# Altium schematic sheet ERF01.SchDoc
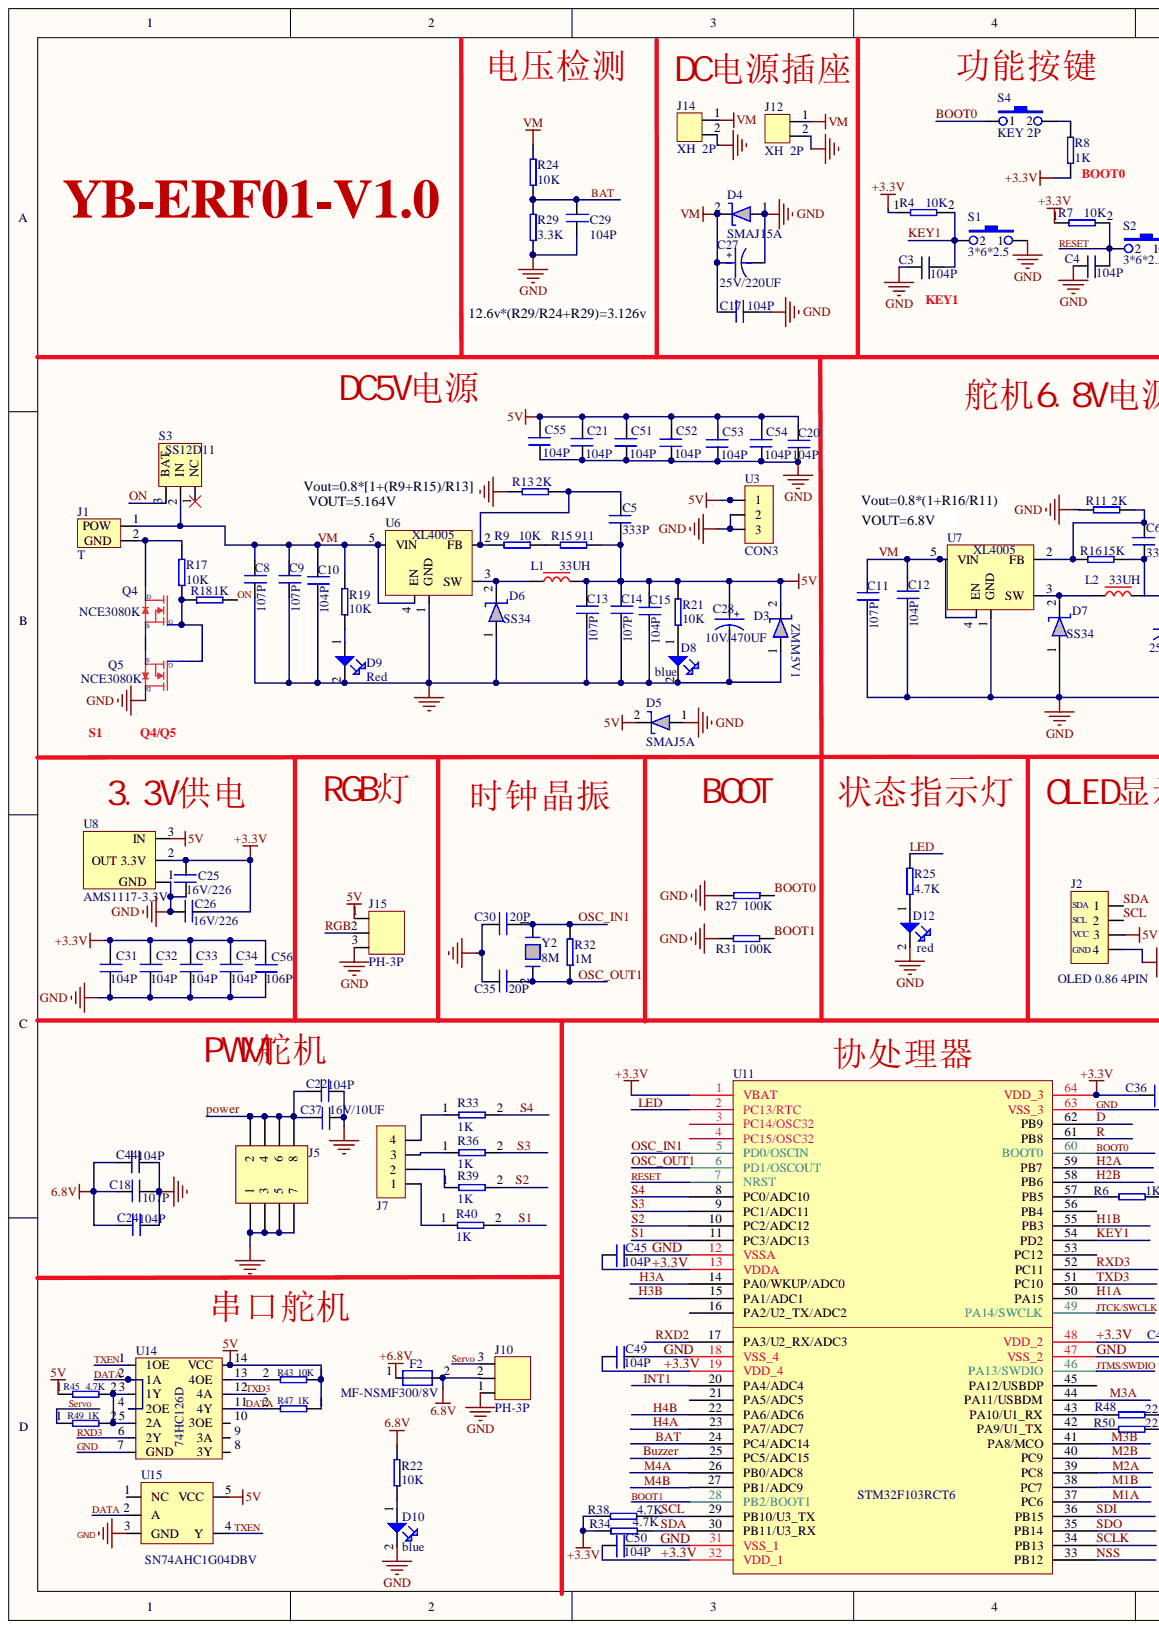
[diagram p0: ERF01.SchDoc, left]
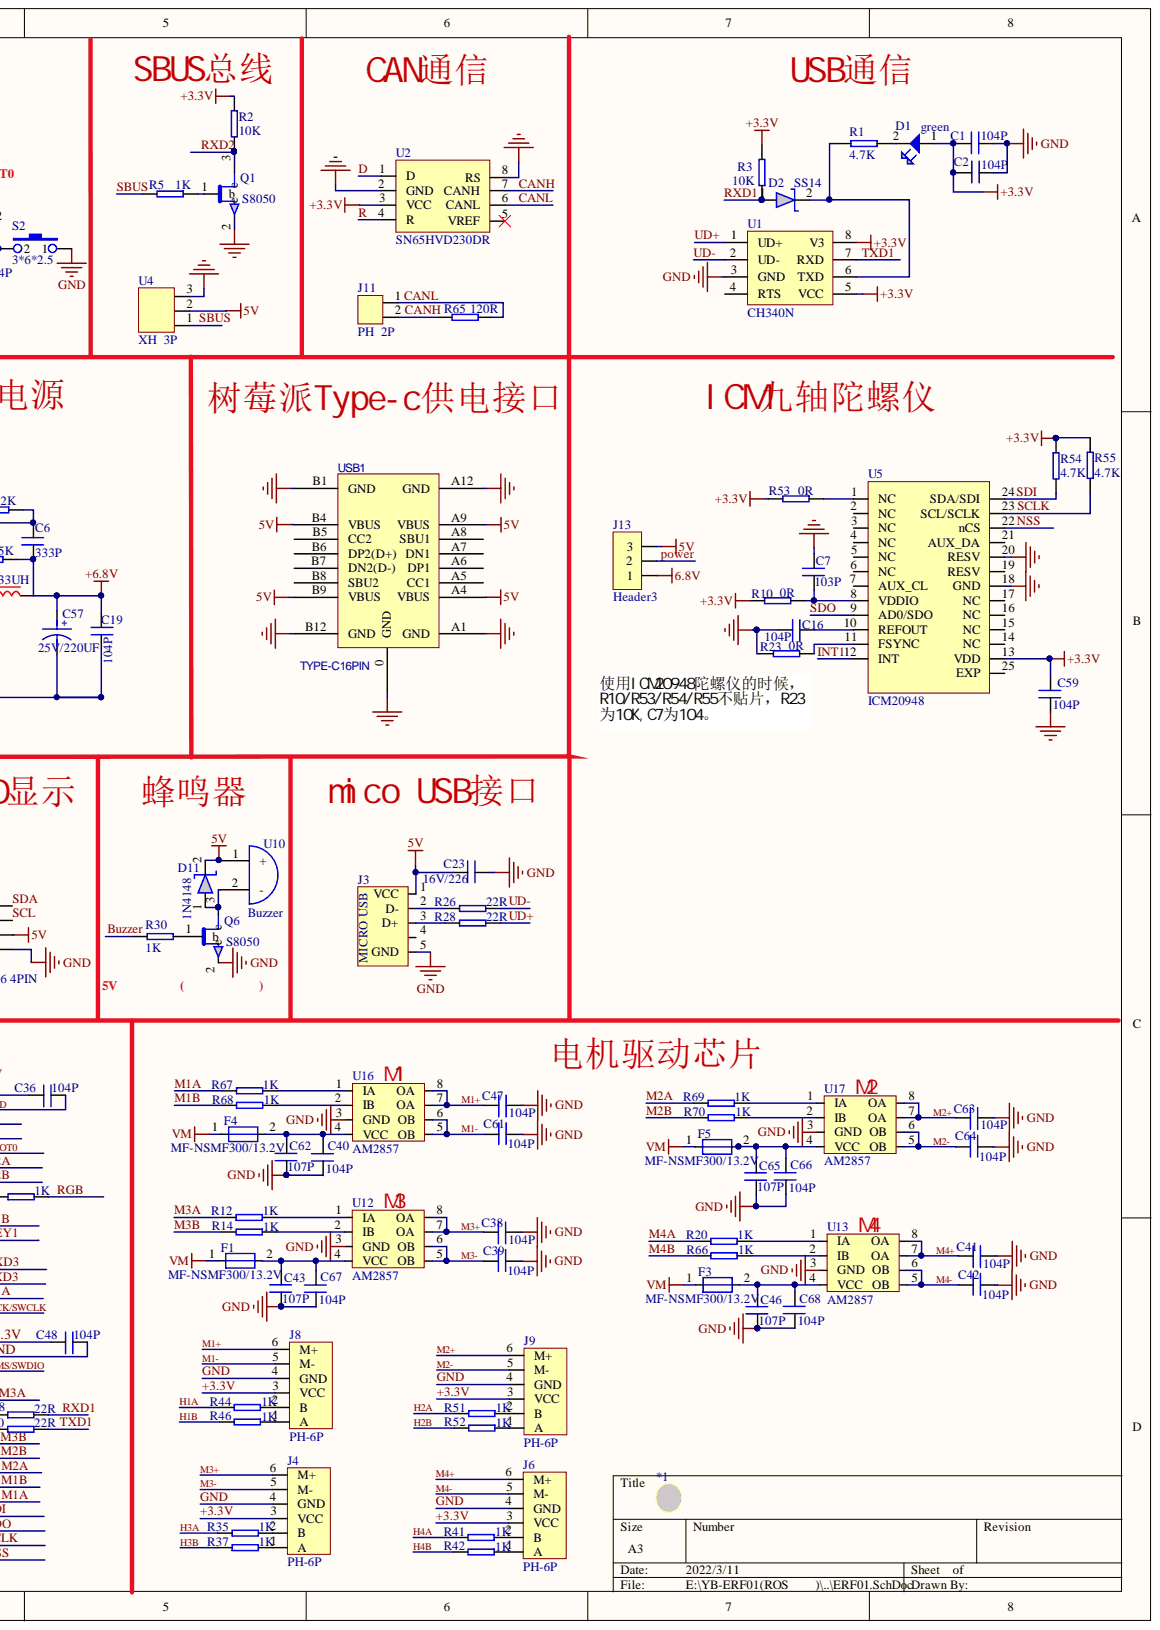
[diagram p1: ERF01.SchDoc, right]

## Parts
*1  (no value)  [LGO18.77MM]
C1  104P  [C0603]
C2  104P  [C0603]
C3  104P  [C0603]
C4  104P  [C0603]
C5  333P  [C0603]
C6  333P  [C0603]
C7  103P  [C0603]
C8  107P  [C1206]
C9  107P  [C1206]
C10  104P  [C0603]
C11  107P  [C1206]
C12  104P  [C0603]
C13  107P  [C1206]
C14  107P  [C1206]
C15  104P  [C0603]
C16  104P  [C0603]
C17  104P  [C0603]
C18  107P  [C1206]
C19  104P  [C0603]
C20  104P  [C0603]
C21  104P  [C0603]
C22  104P  [C0603]
C23  16V/226  [C0603]
C24  104P  [C0603]
C25  16V/226  [C0603]
C26  16V/226  [C0603]
C27  25V/220UF  [C6X7插件]
C28  10V/470UF  [C6X7插件]
C29  104P  [C0603]
C30  20P  [C0603]
C31  104P  [C0603]
C32  104P  [C0603]
C33  104P  [C0603]
C34  104P  [C0603]
C35  20P  [C0603]
C36  104P  [C0603]
C37  16V/10UF  [C0603]
C38  104P  [C0603]
C39  104P  [C0603]
C40  104P  [C0603]
C41  104P  [C0603]
C42  104P  [C0603]
C43  107P  [C1206]
C44  104P  [C0603]
C45  104P  [C0603]
C46  107P  [C1206]
C47  104P  [C0603]
C48  104P  [C0603]
C49  104P  [C0603]
C50  104P  [C0603]
C51  104P  [C0603]
C52  104P  [C0603]
C53  104P  [C0603]
C54  104P  [C0603]
C55  104P  [C0603]
C56  106P  [C0603]
C57  25V/220UF  [C6X7插件]
C59  104P  [C0603]
C61  104P  [C0603]
C62  107P  [C1206]
C63  104P  [C0603]
C64  104P  [C0603]
C65  107P  [C1206]
C66  104P  [C0603]
C67  104P  [C0603]
C68  104P  [C0603]
D1  green  [D0603-G]
D2  SS14  [SMA]
D3  ZMM5V1  [Dio1206]
D4  SMAJ15A  [SMA]
D5  SMAJ5A  [SMA]
D6  SS34  [SMA]
D7  SS34  [SMA]
D8  blue  [D0603-B]
D9  Red  [D0603-R]
D10  blue  [D0603-B]
D11  1N4148  [Dio1206]
D12  red  [D0603-R]
F1  MF-NSMF300/13.2V  [F1206]
F2  MF-NSMF300/8V  [F1812]
F3  MF-NSMF300/13.2V  [F1206]
F4  MF-NSMF300/13.2V  [F1206]
F5  MF-NSMF300/13.2V  [F1206]
J1  T插  [T插]  (value not drawn)
J2  OLED 0.86 4PIN  [OLED-8P]
J3  MICRO USB  [MICRO USB 5P]
J4  PH-6P  卧式  [PH  6P卧式]  (value not drawn)
J5  Header2X4  [HDR2*4]  (value not drawn)
J6  PH-6P  卧式  [PH  6P卧式]  (value not drawn)
J7  Header4  [HDR4]  (value not drawn)
J8  PH-6P  卧式  [PH  6P卧式]  (value not drawn)
J9  PH-6P  卧式  [PH  6P卧式]  (value not drawn)
J10  PH-3P  [PH-3P卧式]
J11  PH  2P  [PH-2P卧式]
J12  XH  2P  [XH 2P卧式]
J13  Header3  [HDR3]
J14  XH  2P  [XH 2P卧式]
J15  PH-3P  [PH-3P卧式]
L1  33UH  [L-33UH]
L2  33UH  [L-33UH]
Q1  S8050  [SOT-23-3]
Q4  NCE3080K  [TO-252-3]
Q5  NCE3080K  [TO-252-3]
Q6  S8050  [SOT-23-3]
R1  4.7K  [R0603]
R2  10K  [R0603]
R3  10K  [R0603]
R4  10K  [R0603]
R5  1K  [R0603]
R6  1K  [R0603]
R7  10K  [R0603]
R8  1K  [R0603]
R9  10K  [R0603]
R10  0R  [R0603]
R11  2K  [R0603]
R12  1K  [R0603]
R13  2K  [R0603]
R14  1K  [R0603]
R15  911  [R0603]
R16  15K  [R0603]  (value not drawn)
R17  10K  [R0603]
R18  1K  [R0603]  (value not drawn)
R19  10K  [R0603]
R20  1K  [R0603]
R21  10K  [R0603]
R22  10K  [R0603]
R23  0R  [R0603]
R24  10K  [R0603]
R25  4.7K  [R0603]
R26  22R  [R0603]
R27  100K  [R0603]
R28  22R  [R0603]
R29  3.3K  [R0603]
R30  1K  [R0603]
R31  100K  [R0603]
R32  1M  [R0603]
R33  1K  [R0603]
R34  4.7K  [R0603]
R35  1K  [R0603]
R36  1K  [R0603]
R37  1K  [R0603]
R38  4.7K  [R0603]
R39  1K  [R0603]
R40  1K  [R0603]
R41  1K  [R0603]
R42  1K  [R0603]
R43  10K  [R0603]
R44  1K  [R0603]
R45  4.7K  [R0603]
R46  1K  [R0603]
R47  1K  [R0603]
R48  22R  [R0603]
R49  1K  [R0603]
R50  22R  [R0603]
R51  1K  [R0603]
R52  1K  [R0603]
R53  0R  [R0603]
R54  4.7K  [R0603]
R55  4.7K  [R0603]
R65  120R  [R0603]
R66  1K  [R0603]
R67  1K  [R0603]
R68  1K  [R0603]
R69  1K  [R0603]
R70  1K  [R0603]
S1  3*6*2.5  [TSW DIP-3*6*5_V]
S2  3*6*2.5  [TSW DIP-3*6*5_V]
S3  SS12D11  [SS12D11  wi]
S4  KEY 2P  [TSW SMD3*6*4.3]
U1  CH340N  [sop-8]
U2  SN65HVD230DR  [SOP-8]
U3  CON3  [DC-IN-TH_DC005]
U4  XH  3P  [HDR3]
U5  ICM20948  [ICM-20948]
U6  XL4005  [XL4005]
U7  XL4005  [XL4005]
U8  AMS1117-3.3V  [SOT-223]
U10  Buzzer  [Buzzer 有源3V  0905]
U11  STM32F103RCT6  [QFP64/P0.5/W12]
U12  AM2857  [SOP-8]
U13  AM2857  [SOP-8]
U14  74HC126D  [SOP-14]
U15  SN74AHC1G04DBV  [SOT-23-5]
U16  AM2857  [SOP-8]
U17  AM2857  [SOP-8]
USB1  TYPE-C16PIN  [USB-C-SMD_TYPE-C16PIN]
Y2  8M  [HC-49S]

## Nets
5V: C5.2 C13.2 C14.2 C15.2 C20.2 C21.1 C23.2 C28.1 C51.1 C52.1 C53.1 C54.1 C55.1 D3.2 D5.2 D11.2 J2.3 J3.1(VCC) J13.3 J15.1 L1.2 R15.1 R21.2 R43.1 R45.1 R47.1 U3.1 U4.2 U8.3 U10.1 U14.14(VCC) U15.5(VCC) USB1.A4(VBUS) USB1.A9(VBUS) USB1.B4(VBUS) USB1.B9(VBUS)
6.8V: C18.2 C24.2 C44.2 F2.2 J10.2 J13.1 R22.2
+6.8V: C6.2 C19.2 C57.1 F2.1 L2.2 R16.1
+3.3V: C1.2 C2.2 C25.1 C26.1 C31.2 C32.2 C33.2 C34.2 C36.1 C45.1 C48.1 C49.1 C50.1 C56.2 C59.1 D1.1 J4.3(VCC) J6.3(VCC) J8.3(VCC) J9.3(VCC) R2.1 R3.2 R4.1 R7.1 R8.1 R10.2 R34.1 R38.1 R53.2 R54.1 R55.1 U1.5(VCC) U1.8(V3) U2.3(VCC) U5.13(VDD) U8.2 U11.1(VBAT) U11.13(VDDA) U11.19(VDD_4) U11.32(VDD_1) U11.48(VDD_2) U11.64(VDD_3)
BAT: C29.2 R24.1 R29.2 U11.24(PC4/ADC14)
BOOT0: R27.1 S4.1 U11.60(BOOT0)
BOOT1: R31.1 U11.28(PB2/BOOT1)
Buzzer: R30.2 U11.25(PC5/ADC15)
CANH: J11.2 R65.2 U2.7(CANH)
CANL: J11.1 R65.1 U2.6(CANL)
D: U2.1(D) U11.62(PB9)
DATA: R47.2 U14.2(1A) U14.4(2OE) U14.11(4Y) U15.2(A)
GND: C1.1 C2.1 C3.1 C4.1 C7.1 C8.1 C9.1 C10.1 C11.1 C12.1 C13.1 C14.1 C15.1 C16.1 C17.1 C18.1 C19.1 C20.1 C21.2 C22.1 C23.1 C24.1 C25.2 C26.2 C27.2 C28.2 C29.1 C30.2 C31.1 C32.1 C33.1 C34.1 C35.2 C36.2 C37.2 C38.2 C39.1 C40.2 C41.2 C42.1 C43.2 C44.1 C45.2 C46.2 C47.2 C48.2 C49.2 C50.2 C51.2 C52.2 C53.2 C54.2 C55.2 C56.1 C57.2 C59.2 C61.1 C62.2 C63.2 C64.1 C65.2 C66.2 C67.2 C68.2 D3.1 D4.1 D5.1 D6.1 D7.1 D8.2 D9.2 D10.2 D12.2 J2.4 J3.5(GND) J4.4(GND) J5.1 J5.3 J5.5 J5.7 J6.4(GND) J8.4(GND) J9.4(GND) J10.1 J12.2 J14.2 J15.3 Q1.2 Q5.3 Q6.2 R11.2 R13.2 R23.2 R27.2 R29.1 R31.2 S1.1 S2.1 U1.3(GND) U2.2(GND) U2.8(RS) U3.2 U3.3 U4.3 U5.18(GND) U5.20(RESV) U6.1(GND) U7.1(GND) U8.1 U11.12(VSSA) U11.18(VSS_4) U11.31(VSS_1) U11.47(VSS_2) U11.63(VSS_3) U12.3(GND) U13.3(GND) U14.7(GND) U15.3(GND) U16.3(GND) U17.3(GND) USB1.0(GND) USB1.A1(GND) USB1.A12(GND) USB1.B1(GND) USB1.B12(GND)
H1A: R44.2 U11.50(PA15)
H1B: R46.2 U11.55(PB3)
H2A: R51.2 U11.59(PB7)
H2B: R52.2 U11.58(PB6)
H3A: R35.1 U11.14(PA0/WKUP/ADC0)
H3B: R37.1 U11.15(PA1/ADC1)
H4A: R41.2 U11.23(PA7/ADC7)
H4B: R42.2 U11.22(PA6/ADC6)
INT1: U5.12(INT) U11.20(PA4/ADC4)
JTCK/SWCLK: U11.49(PA14/SWCLK)
JTMS/SWDIO: U11.46(PA13/SWDIO)
KEY1: C3.2 R4.2 S1.2 U11.54(PD2)
LED: R25.1 U11.2(PC13/RTC)
M1+: C47.1 J8.6(M+) U16.7(OA) U16.8(OA)
M1-: C61.2 J8.5(M-) U16.5(OB) U16.6(OB)
M1A: R67.1 U11.37(PC6)
M1B: R68.2 U11.38(PC7)
M2+: C63.1 J9.6(M+) U17.7(OA) U17.8(OA)
M2-: C64.2 J9.5(M-) U17.5(OB) U17.6(OB)
M2A: R69.1 U11.39(PC8)
M2B: R70.2 U11.40(PC9)
M3+: C38.1 J4.6(M+) U12.7 U12.8(OA)
M3-: C39.2 J4.5(M-) U12.5(OB) U12.6(OB)
M3A: R12.1 U11.44(PA11/USBDM)
M3B: R14.2 U11.41(PA8/MCO)
M4+: C41.1 J6.6(M+) U13.7(OA) U13.8(OA)
M4-: C42.2 J6.5(M-) U13.5(OB) U13.6(OB)
M4A: R20.1 U11.26(PB0/ADC8)
M4B: R66.2 U11.27(PB1/ADC9)
NSS: U5.22(nCS) U11.33(PB12)
ON: R18.2 S3.3
OSC_IN1: C30.1 R32.2 U11.5(PD0/OSCIN) Y2.1
OSC_OUT1: C35.1 R32.1 U11.6(PD1/OSCOUT) Y2.2
power: C22.2 C37.1 J5.2 J5.4 J5.6 J5.8 J13.2
R: U2.4(R) U11.61(PB8)
RESET: C4.2 R7.2 S2.2 U11.7(NRST)
RGB: J15.2 R6.2
RXD1: D2.1 R3.1 R48.2
RXD2: Q1.3 R2.2 U11.17(PA3/U2_RX/ADC3)
RXD3: U11.52(PC11) U14.6(2Y)
S1: R40.2 U11.11(PC3/ADC13)
S2: R39.2 U11.10(PC2/ADC12)
S3: R36.2 U11.9(PC1/ADC11)
S4: R33.2 U11.8(PC0/ADC10)
SBUS: R5.2 U4.1
SCL: J2.2 R38.2 U11.29(PB10/U3_TX)
SCLK: R55.2 U5.23(SCL/SCLK) U11.34(PB13)
SDA: J2.1 R34.2 U11.30(PB11/U3_RX)
SDI: R54.2 U5.24(SDA/SDI) U11.36(PB15)
SDO: U5.9(AD0/SDO) U11.35(PB14)
Servo: J10.3 R49.1
TXD1: R50.2 U1.7(RXD)
TXD3: U11.51(PC10) U14.12
TXEN: U14.1(1OE) U15.4(Y)
UD+: R28.2 U1.1(UD+)
UD-: R26.2 U1.2(UD-)
VM: C8.2 C9.2 C10.2 C11.2 C12.2 C17.2 C27.1 D4.2 F1.1 F3.1 F4.1 F5.1 J1.1 J12.1 J14.1 R19.2 R24.2 S3.2 U6.4(EN) U6.5(VIN) U7.4(EN) U7.5(VIN)
NetC5_1: C5.1 R9.2 R13.1 U6.2(FB)
NetC6_1: C6.1 R11.1 R16.2 U7.2(FB)
NetC7_2: C7.2 R10.1 U5.8(VDDIO)
NetC16_2: C16.2 U5.10(REFOUT)
NetC40_1: C40.1 C62.1 F4.2 U16.4(VCC)
NetC43_1: C43.1 C67.1 F1.2 U12.4(VCC)
NetC46_1: C46.1 C68.1 F3.2 U13.4(VCC)
NetC65_1: C65.1 C66.1 F5.2 U17.4(VCC)
NetD1_2: D1.2 R1.2
NetD2_2: D2.2 R1.1 U1.6(TXD)
NetD6_2: D6.2 L1.1 U6.3(SW)
NetD7_2: D7.2 L2.1 U7.3(SW)
NetD8_1: D8.1 R21.1
NetD9_1: D9.1 R19.1
NetD10_1: D10.1 R22.1
NetD11_1: D11.1 Q6.3 U10.2
NetD12_1: D12.1 R25.2
NetJ1_2: J1.2 Q4.3 R17.2
NetJ3_2: J3.2(D-) R26.1
NetJ3_3: J3.3(D+) R28.1
NetJ4_1: J4.1(A) R37.2
NetJ4_2: J4.2(B) R35.2
NetJ6_1: J6.1(A) R42.1
NetJ6_2: J6.2(B) R41.1
NetJ7_1: J7.1 R40.1
NetJ7_2: J7.2 R39.1
NetJ7_3: J7.3 R36.1
NetJ7_4: J7.4 R33.1
NetJ8_1: J8.1(A) R46.1
NetJ8_2: J8.2(B) R44.1
NetJ9_1: J9.1(A) R52.1
NetJ9_2: J9.2(B) R51.1
NetQ1_1: Q1.1 R5.1
NetQ4_1: Q4.1 Q5.1 R17.1 R18.1
NetQ4_2: Q4.2 Q5.2
NetQ6_1: Q6.1 R30.1
NetR6_1: R6.1 U11.57(PB5)
NetR8_2: R8.2 S4.2
NetR9_1: R9.1 R15.2
NetR12_2: R12.2 U12.1(IA)
NetR14_1: R14.1 U12.2(IB)
NetR20_2: R20.2 U13.1(IA)
NetR23_1: R23.1 U5.11(FSYNC)
NetR43_2: R43.2 U14.13(4OE)
NetR45_2: R45.2 R49.2 U14.3(1Y) U14.5(2A)
NetR48_1: R48.1 U11.43(PA10/U1_RX)
NetR50_1: R50.1 U11.42(PA9/U1_TX)
NetR53_1: R53.1 U5.1(NC)
NetR66_1: R66.1 U13.2(IB)
NetR67_2: R67.2 U16.1(IA)
NetR68_1: R68.1 U16.2(IB)
NetR69_2: R69.2 U17.1(IA)
NetR70_1: R70.1 U17.2(IB)

Not connected: J3 4; S3 1; U1 4(RTS); U2 5(VREF); U5 2(NC) 3(NC) 4(NC) 5(NC) 6(NC) 7(AUX_CL) 14(NC) 15(NC) 16(NC) 17(NC) 19(RESV) 21(AUX_DA) 25(EXP); U11 3(PC14/OSC32) 4(PC15/OSC32) 16(PA2/U2_TX/ADC2) 21(PA5/ADC5) 45(PA12/USBDP) 53(PC12) 56(PB4); U14 8(3Y) 9(3A) 10(3OE); U15 1(NC); USB1 A5(CC1) A6(DP1) A7(DN1) A8(SBU1) B5(CC2) B6(DP2(D+)) B7(DN2(D-)) B8(SBU2)

## Library data of the parts
*1 = LOGO: Component Kind: Standard; Library Name: CZ 3D.IntLib
C1-C26 C29-C56 C59 C61-C68 = Cap: Component Kind: Standard; Library Name: CZ 3D.IntLib
C27 C28 C57 = Cap插件电解: Component Kind: Standard; Library Name: CZ 3D.IntLib
D1 D8-D10 D12 = LED-贴片: Component Kind: Standard; Library Name: CZ 3D.IntLib
D2-D7 D11 = Diode: Component Kind: Standard; Library Name: CZ 3D.IntLib
F1-F5 = F 自恢复: Component Kind: Standard; Library Name: CZ 3D.IntLib
J4 J6 J8 J9 = PH-6P  520霍尔: Component Kind: Standard; Library Name: CZ 3D.IntLib
J11 = XH  2P: Component Kind: Standard; Library Name: CZ 3D.SchLib
L1 L2 = L 贴片: Component Kind: Standard; Library Name: CZ 3D.IntLib
Q1 Q6 = 8050: Component Kind: Standard; Library Name: CZ 3D.IntLib
Q4 Q5 = NMOS: Component Kind: Standard; Library Name: CZ 3D.IntLib
R1-R32 R34 R35 R37 R38 R41-R55 R65-R70 = Res: Component Kind: Standard; Library Name: CZ 3D.IntLib
R33 R36 R39 R40 = RN: Component Kind: Standard; Library Name: Component.PcbLib
S1 S2 = KEY 2P: Component Kind: Standard; Library Name: CZ 3D.IntLib
S3 = SW Toggle: Component Kind: Standard; Library Name: CZ 3D.IntLib
U1 = Component_1: Component Kind: Standard; Library Name: 340N.SchLib
U8 = AMS1117: Component Kind: Standard; Library Name: CZ 3D.IntLib
USB1 = TYPE-C16PIN: BOM_Manufacturer: SHOU HAN; Contributor: LCSC; BOM_Manufacturer Part: TYPE-C16PIN; BOM_Supplier: LCSC; BOM_Supplier Part: C393939; Component Kind: Standard; Library Name: CZ 3D.SchLib; spicePre: U; spiceSymbolName: TYPE-C16PIN
Y2 = XTAL: Component Kind: Standard; Library Name: CZ 3D.IntLib
J1 = T插: Component Kind: Standard; Library Name: CZ 3D.IntLib
J2 = OLED 0.86 4PIN: Component Kind: Standard; Library Name: CZ 3D.IntLib
J3 = MICRO USB: Component Kind: Standard; Library Name: CZ 3D.IntLib
J5 = Header2X4: Component Kind: Standard; Library Name: CZ 3D.IntLib
J7 = Header4: Component Kind: Standard; Library Name: CZ 3D.IntLib
J10 J15 = PH-3P: Component Kind: Standard; Library Name: CZ 3D.IntLib
J12 J14 = XH  2P: Component Kind: Standard; Library Name: CZ 3D.SchLib
J13 = Header3: Component Kind: Standard; Library Name: CZ 3D.SchLib
S4 = KEY 2P: Component Kind: Standard; Library Name: CZ 3D.SchLib
U2 = SN65HVD230DR: Component Kind: Standard; Library Name: CZ 3D.SchLib
U3 = CON3: Component Kind: Standard; Library Name: CZ 3D.SchLib
U4 = XH  3P: Component Kind: Standard; Library Name: CZ 3D.SchLib
U5 = ICM20948: Component Kind: Standard; Library Name: CZ 3D.SchLib
U6 U7 = XL4005: Component Kind: Standard; Library Name: CZ 3D.IntLib
U10 = Buzzer: Component Kind: Standard; Library Name: CZ 3D.IntLib
U11 = STM32F103RCT6: Component Kind: Standard; Library Name: ziyong.IntLib
U12 U13 U16 U17 = AM2857: Component Kind: Standard; Library Name: CZ 3D.IntLib
U14 = 74HC126D: Component Kind: Standard; Library Name: CZ 3D.IntLib
U15 = SN74AHC1G04DBV: Component Kind: Standard; Library Name: CZ 3D.IntLib
